# Altium schematic sheet ESP8266.SchDoc(ESP8266)
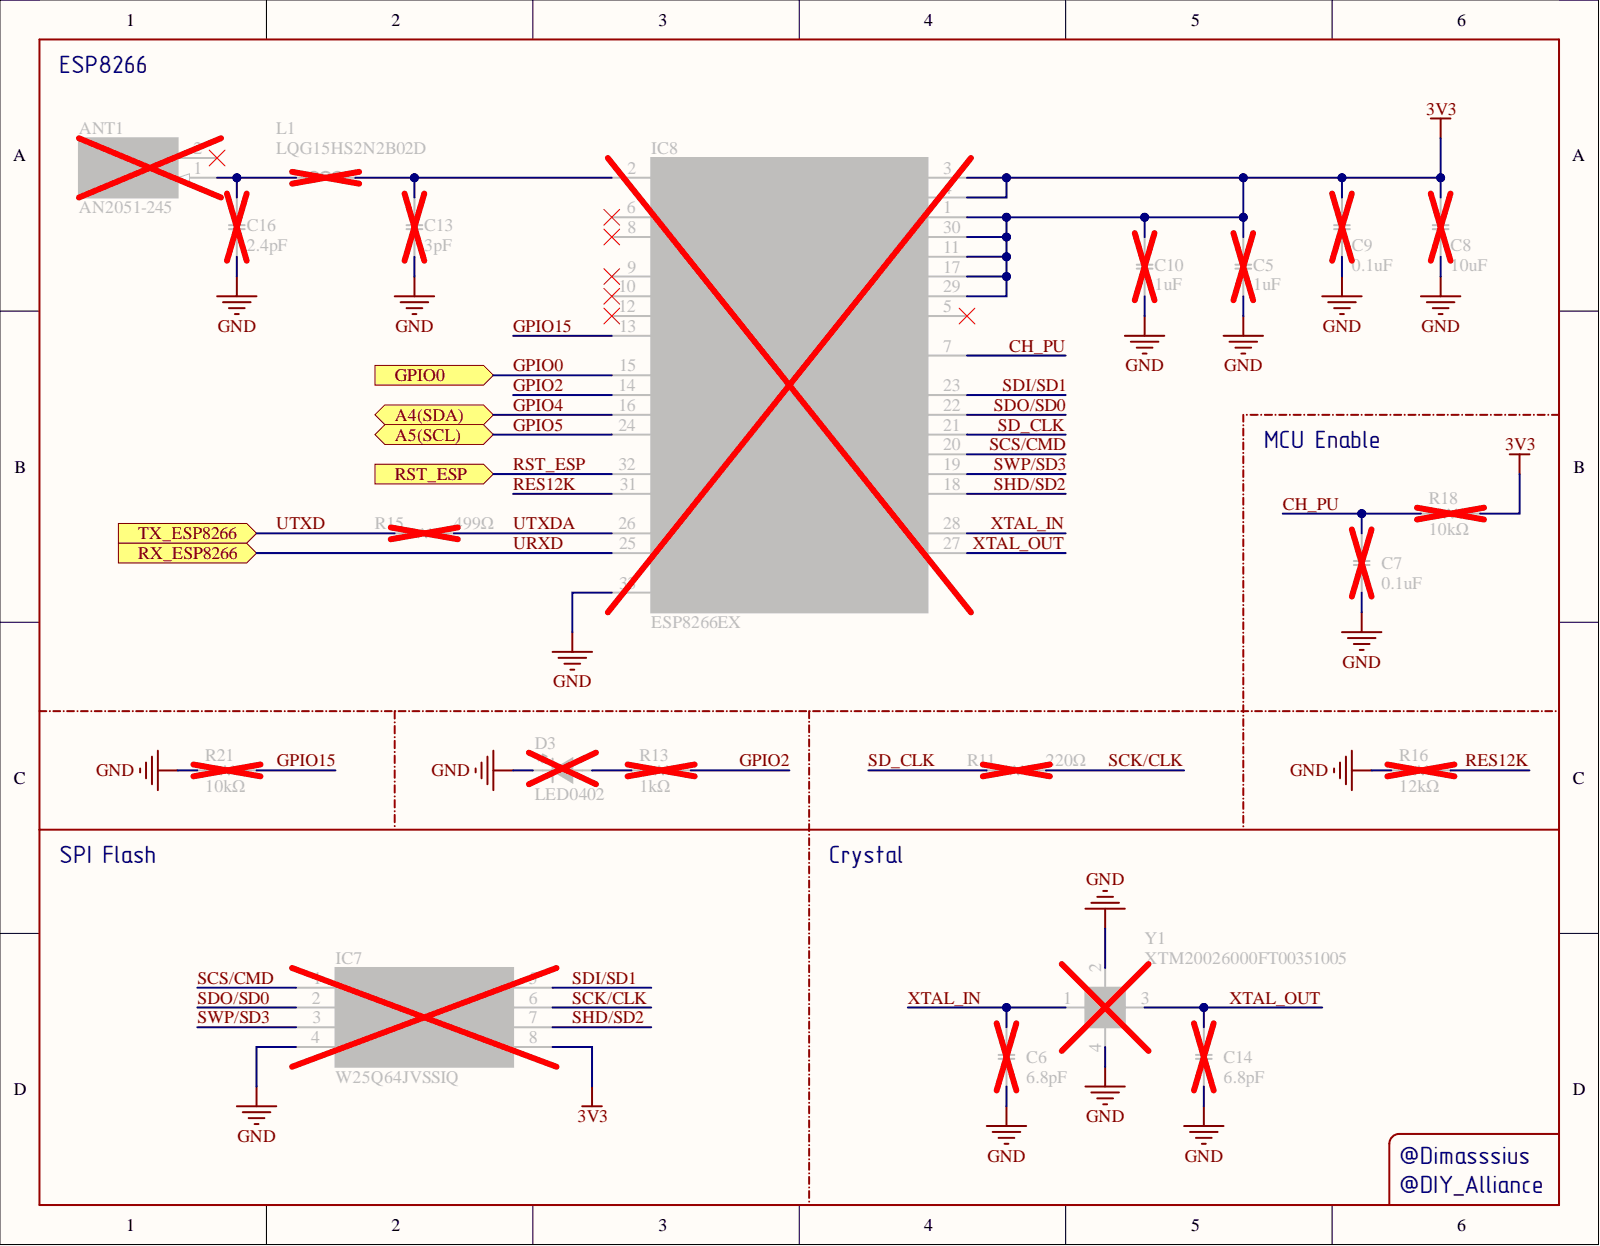
[diagram p0: ESP8266.SchDoc]

## Parts
ANT1  AN2051-245  [ANTC2051]
C5  1uF  [CAP_SMD_MLCC_C0402_(M1005)]
C6  6.8pF  [CAP_SMD_MLCC_C0402_(M1005)]
C7  0.1uF  [CAP_SMD_MLCC_C0402_(M1005)]
C8  10uF  [CAP_SMD_MLCC_C0402_(M1005)]
C9  0.1uF  [CAP_SMD_MLCC_C0402_(M1005)]
C10  1uF  [CAP_SMD_MLCC_C0402_(M1005)]
C13  3pF  [CAP_SMD_MLCC_C0402_(M1005)]
C14  6.8pF  [CAP_SMD_MLCC_C0402_(M1005)]
C16  2.4pF  [CAP_SMD_MLCC_C0402_(M1005)]
D3  LED0402  [LED_SMD_0402_(M1005)_GREEN]
IC7  W25Q64JVSSIQ  [SOIC127P798X216-8N]
IC8  ESP8266EX  [QFN50P500X500X90-33N-D]
L1  LQG15HS2N2B02D  [IND_SMD_0402_(M1005)]
R11  220Ω  [RES_SMD_R0402_(M1005)]
R13  1kΩ  [RES_SMD_R0402_(M1005)]
R15  499Ω  [RES_SMD_R0402_(M1005)]
R16  12kΩ  [RES_SMD_R0402_(M1005)]
R18  10kΩ  [RES_SMD_R0402_(M1005)]
R21  10kΩ  [RES_SMD_R0402_(M1005)]
Y1  XTM20026000FT00351005  [XTL_4_3225]

## Ports
A4(SDA), A5(SCL), GPIO0, RST_ESP, RX_ESP8266, TX_ESP8266

## Nets
3V3: C5.2 C8.2 C9.2 C10.1 IC7.8(VCC) IC8.1(VDDA_1) IC8.3(VDD3P3_1) IC8.4(VDD3P3_2) IC8.11(VDDPST_1) IC8.17(VDDPST_2) IC8.29(VDDD) IC8.30(VDDA_2) R18.1
GND: C5.1 C6.1 C7.1 C8.1 C9.1 C10.2 C13.2 C14.2 C16.2 D3.1 IC7.4(GND) IC8.33(EP_(GND)) R16.2 R21.1 Y1.2 Y1.4
CH_PU: C7.2 IC8.7(CHIP_EN) R18.2
GPIO0: IC8.15(GPIO0) ; port GPIO0
GPIO2: IC8.14(DPIO2) R13.2
GPIO4: IC8.16(GPIO4) ; port A4(SDA)
GPIO5: IC8.24(GPIO5) ; port A5(SCL)
GPIO15: IC8.13(MTDO) R21.2
RES12K: IC8.31(RES12K) R16.1
RST_ESP: IC8.32(EXT_RSTB) ; port RST_ESP
SCK/CLK: IC7.6(CLK) R11.2
SCS/CMD: IC7.1(CS) IC8.20(SD_CMD)
SD_CLK: IC8.21(SD_CLK) R11.1
SDI/SD1: IC7.5(MOSI) IC8.23(SD_DATA_1)
SDO/SD0: IC7.2(MISO) IC8.22(SD_DATA_0)
SHD/SD2: IC7.7(HOLD) IC8.18(SD_DATA_2)
SWP/SD3: IC7.3(WP) IC8.19(SD_DATA_3)
URXD: IC8.25(U0RXD) ; port RX_ESP8266
UTXD: R15.2 ; port TX_ESP8266
UTXDA: IC8.26(U0TXD) R15.1
XTAL_IN: C6.2 IC8.28(XTAL_IN) Y1.1
XTAL_OUT: C14.1 IC8.27(XTAL_OUT) Y1.3
NetANT1_1: ANT1.1 C16.1 L1.1
NetC13_1: C13.1 IC8.2(LNA) L1.2
NetD3_2: D3.2 R13.1

Not connected: ANT1 2; IC8 5(VDD_RTC) 6(TOUT) 8(XPD_DCDC) 9(MTMS) 10(MTDI) 12(MTCK)

## Library data of the parts
ANT1 = AN2051-245: Antenna, SMD, 2x5.1mm, 2, 0.5dBi, 110MHz, 2.45GHz, Antennas ROHS; Manufacturer_Name: RAINBOW SW INDUSTRY  CO.,LIMITED; Component Kind: Standard; Datasheet Link: https://www.micros.com.pl/mediaserver/info-rf%20an2051-245.pdf; Height: 1.27mm; Library Name: SamacSys.SchLib; Manufacturer_Part_Number: AN2051-245; Value: AN2051-245
C5 C10 = CAP_SMD_MLCC_1UF_6.3V: Capacitor, Ceramic (MLCC), SMD, 1uF, 6.3V, ±10%, X5R, Multilayer Ceramic Capacitors MLCC - SMD/SMT, ROHS; Manufacturer: Murata Electronics North America; Part Number: GRM319R61A105KA01D; @ qty: 0; Minimum Quantity: 1; Unit Price (USD): 0.28; Voltage: 10V; Applications: General Purpose; Component Kind: Standard; Datasheets: http://www.murata.com/~/media/webrenewal/support/library/catalog/products/capacitor/mlcc/c02e.pdf; Digi-Key Part Number: 490-11607-1-ND; Factory Stock: 0; Features: -; Height - Seated (Max): -; Image: //media.digikey.com/Renders/Murata%20Renders/GR331BD72E473KW01L.jpg; Lead Spacing: -; Lead Style: -; Library Name: Capacitors.SchLib; Mounting Type: Surface Mount, MLCC; Operating Temperature: -55°C ~ 85°C; Package / Case: -; Packaging: Cut Tape (CT); Part Status: Active; Quantity Available: 3593; Ratings: -; Series: GRM; Size / Dimension: -; Temperature Coefficient: X5R; Thickness (Max): -; Tolerance: ±10%; Value: 1uF
C6 C14 = CAP_SMD_MLCC_6.8PF_50V: Capacitor, Ceramic (MLCC), SMD, 6.8pF, 50V, ±5%, C0G, Multilayer Ceramic Capacitors MLCC - SMD/SMT, ROHS; Manufacturer: Murata Electronics North America; Part Number: GRM319R71C224KA01D; @ qty: 0; Minimum Quantity: 1; Temperature Coefficient: X7R; Tolerance: ±10%; Unit Price (USD): 0.26; Voltage: 16V; Applications: General Purpose; Component Kind: Standard; Datasheets: http://www.murata.com/~/media/webrenewal/support/library/catalog/products/capacitor/mlcc/c02e.pdf; Digi-Key Part Number: 490-11608-1-ND; Factory Stock: 0; Features: -; Height - Seated (Max): -; Image: //media.digikey.com/Renders/Murata%20Renders/GR331BD72E473KW01L.jpg; Lead Spacing: -; Lead Style: -; Library Name: Capacitors.SchLib; Mounting Type: Surface Mount, MLCC; Operating Temperature: -55°C ~ 125°C; Package / Case: -; Packaging: Cut Tape (CT); Part Status: Active; Quantity Available: 10161; Ratings: -; Series: GRM; Size / Dimension: -; Thickness (Max): -; Value: 6.8pF
C7 C9 = CAP_SMD_MLCC_0.1UF_6.3V: Capacitor, Ceramic (MLCC), SMD, 100nF, 6.3V, ±10%, X7R, Multilayer Ceramic Capacitors MLCC - SMD/SMT, ROHS; Manufacturer: Murata Electronics North America; Part Number: LLM315R71C104MA11L; @ qty: 0; Minimum Quantity: 1; Tolerance: ±20%; Unit Price (USD): 0.9; Voltage: 16V; Applications: Bypass, Decoupling; Component Kind: Standard; Datasheets: http://www.murata.com/~/media/webrenewal/support/library/catalog/products/capacitor/mlcc/c02e.pdf; Digi-Key Part Number: 490-4495-1-ND; Factory Stock: 0; Features: Low ESL (Multi-Terminal); Height - Seated (Max): -; Image: //media.digikey.com/Renders/Murata%20Renders/1206(LLM315).jpg; Lead Spacing: -; Lead Style: -; Library Name: Capacitors.SchLib; Mounting Type: Surface Mount, MLCC; Operating Temperature: -55°C ~ 125°C; Package / Case: -; Packaging: Cut Tape (CT); Part Status: Active; Quantity Available: 2915; Ratings: -; Series: LLM; Size / Dimension: -; Temperature Coefficient: X7R; Thickness (Max): -; Value: 0.1uF
C8 = CAP_SMD_MLCC_10UF_6.3V: Capacitor, Ceramic (MLCC), SMD, 10uF, 6.3V, ±20%, X5R, Multilayer Ceramic Capacitors MLCC - SMD/SMT, ROHS; Manufacturer: Murata Electronics North America; Part Number: GRM31CR60J106KA01L; @ qty: 0; Minimum Quantity: 1; Tolerance: ±10%; Unit Price (USD): 0.24; Applications: General Purpose; Component Kind: Standard; Datasheets: http://www.murata.com/~/media/webrenewal/support/library/catalog/products/capacitor/mlcc/c02e.pdf; Digi-Key Part Number: 490-12610-1-ND; Factory Stock: 0; Features: -; Height - Seated (Max): -; Image: //media.digikey.com/Renders/Murata%20Renders/GR331BD72E473KW01L.jpg; Lead Spacing: -; Lead Style: -; Library Name: Capacitors.SchLib; Mounting Type: Surface Mount, MLCC; Operating Temperature: -55°C ~ 85°C; Package / Case: -; Packaging: Cut Tape (CT); Part Status: Active; Quantity Available: 12001; Ratings: -; Series: GRM; Size / Dimension: -; Temperature Coefficient: X5R; Thickness (Max): -; Value: 10uF; Voltage: 6.3V
C13 = CAP_SMD_MLCC_3PF_50V: Capacitor, Ceramic (MLCC), SMD, 3pF, 50V, ±5%, C0G, Multilayer Ceramic Capacitors MLCC - SMD/SMT, ROHS; Manufacturer: Murata Electronics North America; Part Number: GRM31CR60J106KA01L; @ qty: 0; Minimum Quantity: 1; Temperature Coefficient: X5R; Tolerance: ±10%; Unit Price (USD): 0.24; Voltage: 6.3V; Applications: General Purpose; Component Kind: Standard; Datasheets: http://www.murata.com/~/media/webrenewal/support/library/catalog/products/capacitor/mlcc/c02e.pdf; Digi-Key Part Number: 490-12610-1-ND; Factory Stock: 0; Features: -; Height - Seated (Max): -; Image: //media.digikey.com/Renders/Murata%20Renders/GR331BD72E473KW01L.jpg; Lead Spacing: -; Lead Style: -; Library Name: Capacitors.SchLib; Mounting Type: Surface Mount, MLCC; Operating Temperature: -55°C ~ 85°C; Package / Case: -; Packaging: Cut Tape (CT); Part Status: Active; Quantity Available: 12001; Ratings: -; Series: GRM; Size / Dimension: -; Thickness (Max): -; Value: 3pF
C16 = CAP_SMD_MLCC_2.4PF_50V: Capacitor, Ceramic (MLCC), SMD, 2.4pF, 50V, ±5%, C0G, Multilayer Ceramic Capacitors MLCC - SMD/SMT, ROHS; Manufacturer: Murata Electronics North America; Part Number: GRM31CR60J106KA01L; @ qty: 0; Minimum Quantity: 1; Temperature Coefficient: X5R; Tolerance: ±10%; Unit Price (USD): 0.24; Voltage: 6.3V; Applications: General Purpose; Component Kind: Standard; Datasheets: http://www.murata.com/~/media/webrenewal/support/library/catalog/products/capacitor/mlcc/c02e.pdf; Digi-Key Part Number: 490-12610-1-ND; Factory Stock: 0; Features: -; Height - Seated (Max): -; Image: //media.digikey.com/Renders/Murata%20Renders/GR331BD72E473KW01L.jpg; Lead Spacing: -; Lead Style: -; Library Name: Capacitors.SchLib; Mounting Type: Surface Mount, MLCC; Operating Temperature: -55°C ~ 85°C; Package / Case: -; Packaging: Cut Tape (CT); Part Status: Active; Quantity Available: 12001; Ratings: -; Series: GRM; Size / Dimension: -; Thickness (Max): -; Value: 2.4pF
D3 = XL-1005UGC: LED Indication - Discrete, 0402, 25mA, 249mcd, Colorless, Transparent Lens, -30℃~+85℃, Positive Stick, 516nm~531nm, Emerald, 120°, 80mW, 3V, LED Indication - Discrete, ROHS; Component Kind: Standard; Library Name: SamacSys.SchLib; Value: LED0402
IC7 = W25Q64JVSSIQ: NOR FLASH, SOIC-8-208mil, 64Mbit, SPI, 133MHz, NOR FLASH, ROHS; Component Kind: Standard; Library Name: SamacSys.SchLib; Value: W25Q64JVSSIQ
IC8 = ESP8266EX: Microcontroller, QFN-32-EP(5x5), 72.2Mbps, 2400MHz~2483.5MHz, WiFi 2.4GHz, RF Transceiver ICs, ROHS; Component Kind: Standard; Height: 0.9mm; Library Name: SamacSys.SchLib; Value: ESP8266EX
L1 = LQG15HS2N2B02D: Fixed Inductor, SMD, 0402, 2.2nH, 900mA, ±5%, 90mΩ, Fixed Inductors, RoHS; Component Kind: Standard; Library Name: SamacSys.SchLib; Value: LQG15HS2N2B02D
R11 = RES_SMD_220Ω: Resistor, Thick Film Resistor, SMD, 220Ω, ±5%, Chip Resistor - Surface Mount, ROHS; Component Kind: Standard; Library Name: Resistors.SchLib; Value: 220Ω
R13 = RES_SMD_1KΩ: Resistor, Thick Film Resistor, SMD, 1kΩ, ±5%, Chip Resistor - Surface Mount, ROHS; Component Kind: Standard; Library Name: Resistors.SchLib; Value: 1kΩ
R15 = RES_SMD_499Ω: Resistor, Thick Film Resistor, SMD, 499Ω, ±5%, Chip Resistor - Surface Mount, ROHS; Component Kind: Standard; Library Name: Resistors.SchLib; Value: 499Ω
R16 = RES_SMD_12KΩ: Resistor, Thick Film Resistor, SMD, 12kΩ, ±5%, Chip Resistor - Surface Mount, ROHS; Component Kind: Standard; Library Name: Resistors.SchLib; Value: 12kΩ
R18 R21 = RES_SMD_10KΩ: Resistor, Thick Film Resistor, SMD, 10kΩ, ±5%, Chip Resistor - Surface Mount, ROHS; Component Kind: Standard; Library Name: Resistors.SchLib; Value: 10kΩ
Y1 = TAXM8M4RFDCET2T: Crystal Oscillator, SMD, SMD3225-4P, 3.2x2.5mm, 26MHz, 12pF, ±10ppm, ±20ppm, Crystals, ROHS; Component Kind: Standard; Datasheet Link: https://datasheet.datasheetarchive.com/originals/distributors/Datasheets_SAMA/e448b11b6d77781431a8823f463adabd.pdf; Height: 0.9mm; Library Name: SamacSys.SchLib; Value: XTM20026000FT00351005
